# Altium schematic sheet LTC3350.SchDoc(LTC3350)
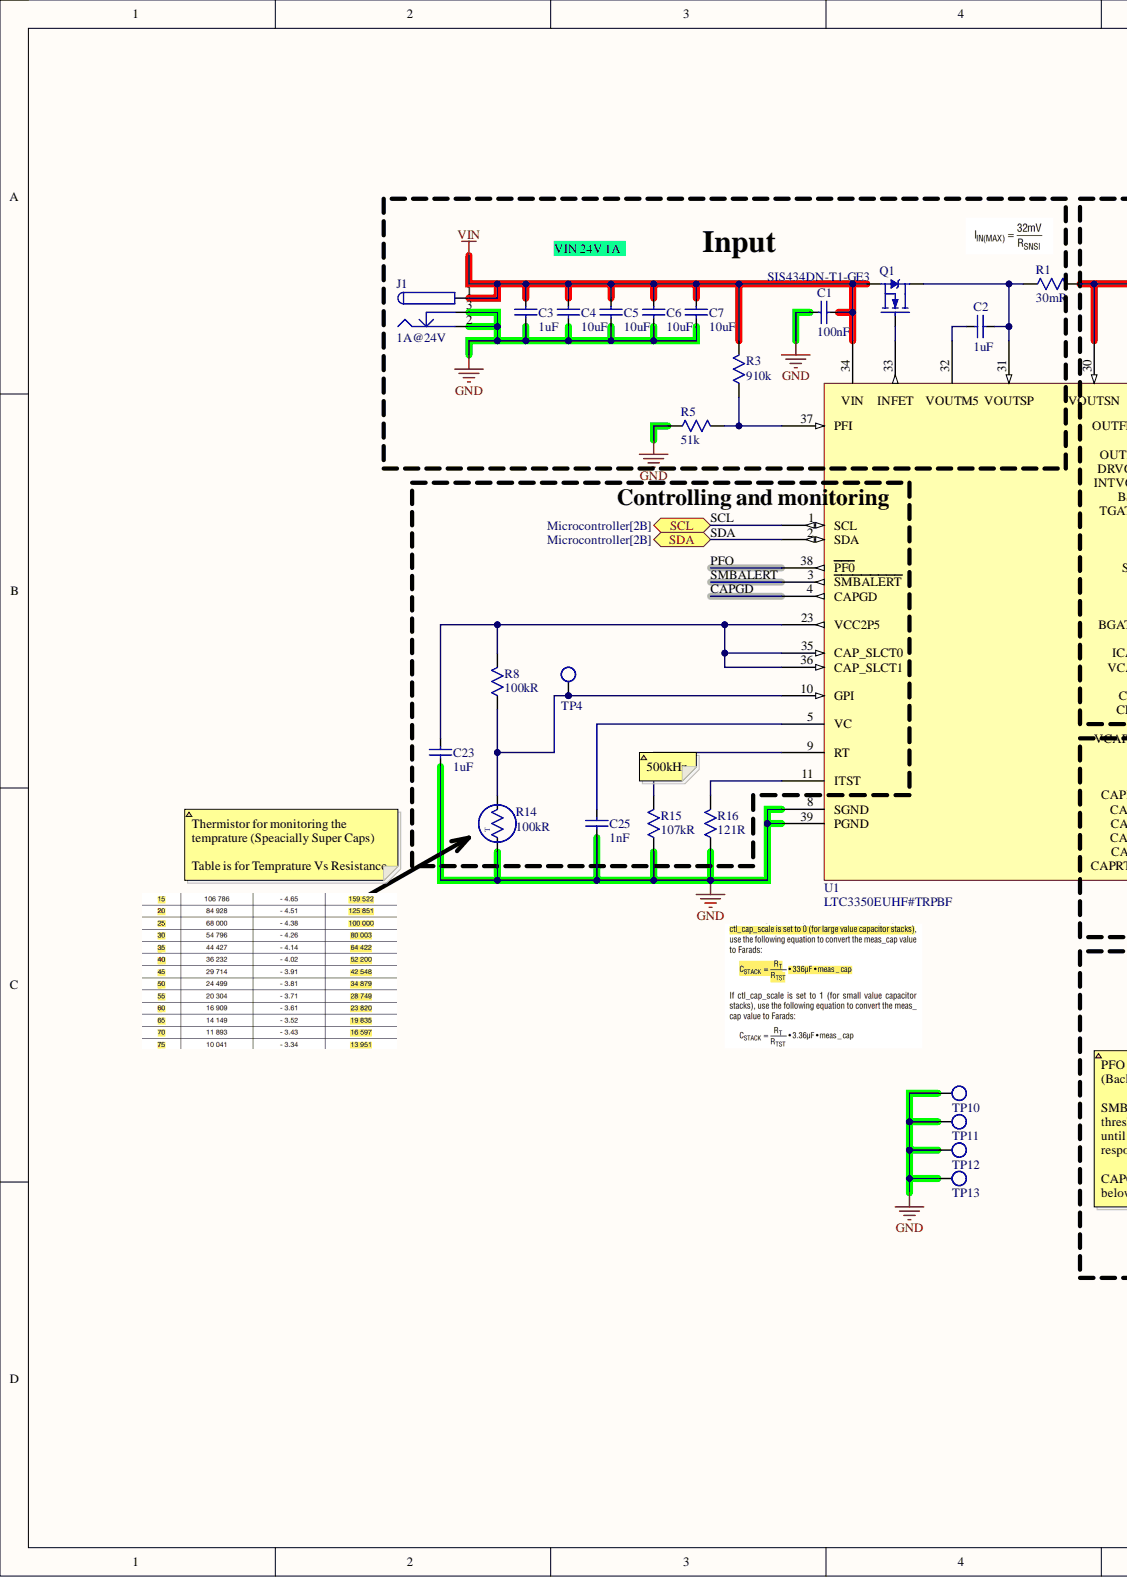
[diagram p0: LTC3350.SchDoc, left]
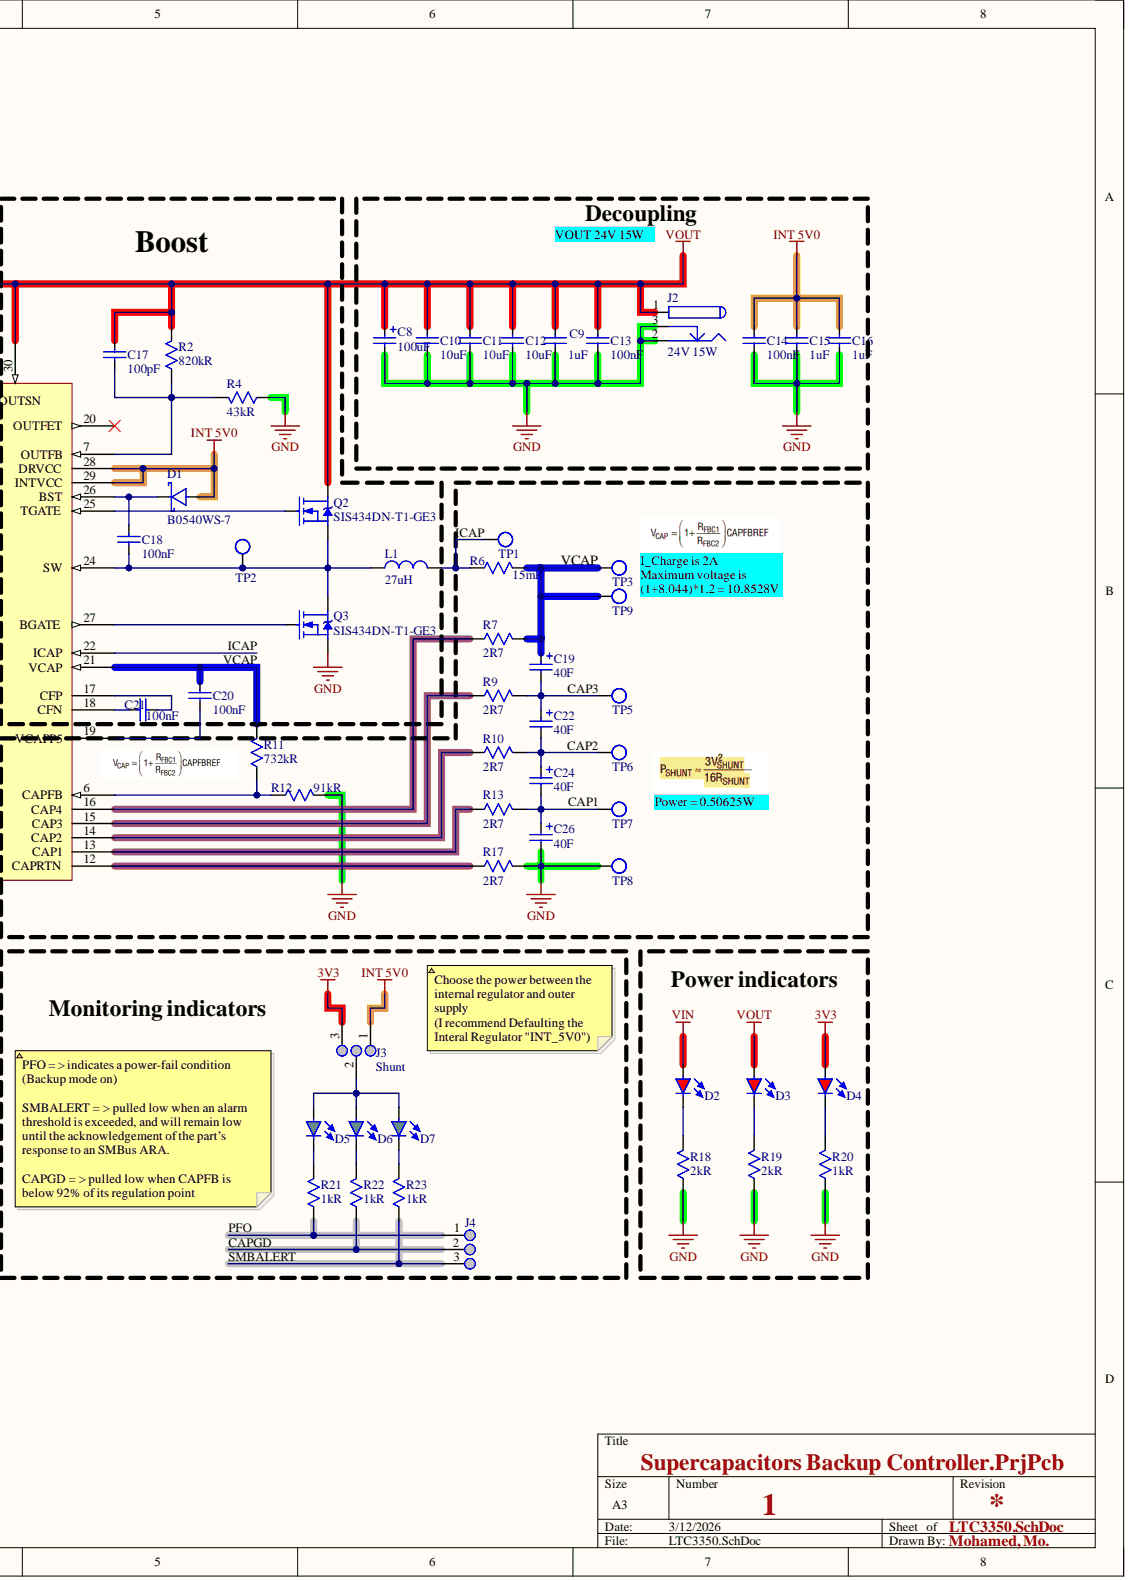
[diagram p1: LTC3350.SchDoc, right]

## Parts
C1  100nF
C2  1uF
C3  1uF
C4  10uF
C5  10uF
C6  10uF
C7  10uF
C8  100uF
C9  1uF
C10  10uF
C11  10uF
C12  10uF
C13  100nF
C14  100nF
C15  1uF
C16  1uF
C17  100pF
C18  100nF
C19  40F
C20  100nF
C21  100nF
C22  40F
C23  1uF
C24  40F
C25  1nF
C26  40F
D1  B0540WS-7
D2  LED 0805 RED  (value not drawn)
D3  LED 0805 RED  (value not drawn)
D4  LED 0805 RED  (value not drawn)
D5  LED 0805 Emerald  (value not drawn)
D6  LED 0805 Emerald  (value not drawn)
D7  LED 0805 Emerald  (value not drawn)
J1  1A@24V
J2  24V 15W
J3  Shunt
J4  Headers 3P  (value not drawn)
L1  27uH
Q1  SIS434DN-T1-GE3
Q2  SIS434DN-T1-GE3
Q3  SIS434DN-T1-GE3
R1  30mR
R2  820kR
R3  910k
R4  43kR
R5  51k
R6  15mR
R7  2R7
R8  100kR
R9  2R7
R10  2R7
R11  732kR
R12  91kR
R13  2R7
R14  100kR
R15  107kR
R16  121R
R17  2R7
R18  2kR
R19  2kR
R20  1kR
R21  1kR
R22  1kR
R23  1kR
TP1  TestPoint  (value not drawn)  (no BOM)
TP2  TestPoint  (value not drawn)  (no BOM)
TP3  TestPoint  (value not drawn)  (no BOM)
TP4  TestPoint  (value not drawn)  (no BOM)
TP5  TestPoint  (value not drawn)  (no BOM)
TP6  TestPoint  (value not drawn)  (no BOM)
TP7  TestPoint  (value not drawn)  (no BOM)
TP8  TestPoint  (value not drawn)  (no BOM)
TP9  TestPoint  (value not drawn)  (no BOM)
TP10  TestPoint  (value not drawn)  (no BOM)
TP11  TestPoint  (value not drawn)  (no BOM)
TP12  TestPoint  (value not drawn)  (no BOM)
TP13  TestPoint  (value not drawn)  (no BOM)
U1  LTC3350EUHF#TRPBF

## Ports
SCL, SDA

## Nets
3V3: D4.1 J3.3
GND: C1.1 C3.2 C4.2 C5.2 C6.2 C7.2 C8.2 C9.2 C10.2 C11.2 C12.2 C13.2 C14.2 C15.2 C16.2 C23.2 C25.2 C26.2 J1.2 J1.3 J2.2 J2.3 Q3.3 R4.1 R5.1 R12.2 R14.1 R15.1 R16.1 R17.2 R18.1 R19.1 R20.1 TP8.1 TP10.1 TP11.1 TP12.1 TP13.1 U1.8(SGND) U1.39(PGND)
INT 5V0: C14.1 C15.1 C16.1 D1.1 J3.1 U1.28(DRVCC) U1.29(INTVCC)
VIN: C1.2 C3.1 C4.1 C5.1 C6.1 C7.1 D2.1 J1.1 Q1.3 R3.2 U1.34
VOUT: C8.1 C9.1 C10.1 C11.1 C12.1 C13.1 C17.1 D3.1 J2.1 Q2.2 R1.2 R2.2 U1.30
CAP1: C24.2 C26.1 R13.2 TP7.1
CAP2: C22.2 C24.1 R10.2 TP6.1
CAP3: C19.2 C22.1 R9.2 TP5.1
CAPGD: J4.2 R22.1 U1.4(CAPGD)
ICAP: L1.1 R6.1 TP1.1 U1.22(ICAP)
PFO: J4.1 R21.1 U1.38(PF0)
SCL: U1.1(SCL) ; port SCL
SDA: U1.2(SDA) ; port SDA
SMBALERT: J4.3 R23.1 U1.3(SMBALERT)
VCAP: C19.1 C20.1 R6.2 R7.2 R11.2 TP3.1 TP9.1 U1.21(VCAP)
NetC2_1: C2.1 U1.32
NetC2_2: C2.2 Q1.2 R1.1 U1.31
NetC17_2: C17.2 R2.1 R4.2 U1.7(OUTFB)
NetC18_1: C18.1 D1.2 U1.26(BST)
NetC18_2: C18.2 L1.2 Q2.3 Q3.2 TP2.1 U1.24(SW)
NetC20_2: C20.2 U1.19(VCAPP5)
NetC21_1: C21.1 U1.18(CFN)
NetC21_2: C21.2 U1.17(CFP)
NetC23_1: C23.1 R8.2 U1.23(VCC2P5) U1.35(CAP_SLCT0) U1.36(CAP_SLCT1)
NetC25_1: C25.1 U1.5(VC)
NetD2_2: D2.2 R18.2
NetD3_2: D3.2 R19.2
NetD4_2: D4.2 R20.2
NetD5_1: D5.1 D6.1 D7.1 J3.2
NetD5_2: D5.2 R21.2
NetD6_2: D6.2 R22.2
NetD7_2: D7.2 R23.2
NetQ1_1: Q1.1 U1.33
NetQ2_1: Q2.1 U1.25(TGATE)
NetQ3_1: Q3.1 U1.27(BGATE)
NetR3_1: R3.1 R5.2 U1.37(PFI)
NetR7_1: R7.1 U1.16(CAP4)
NetR8_1: R8.1 R14.2 TP4.1 U1.10(GPI)
NetR9_1: R9.1 U1.15(CAP3)
NetR10_1: R10.1 U1.14(CAP2)
NetR11_1: R11.1 R12.1 U1.6(CAPFB)
NetR13_1: R13.1 U1.13(CAP1)
NetR15_2: R15.2 U1.9(RT)
NetR16_2: R16.2 U1.11(ITST)
NetR17_1: R17.1 U1.12(CAPRTN)

Not connected: U1 20(OUTFET)
